# Altium schematic sheet JTAG.SchDoc
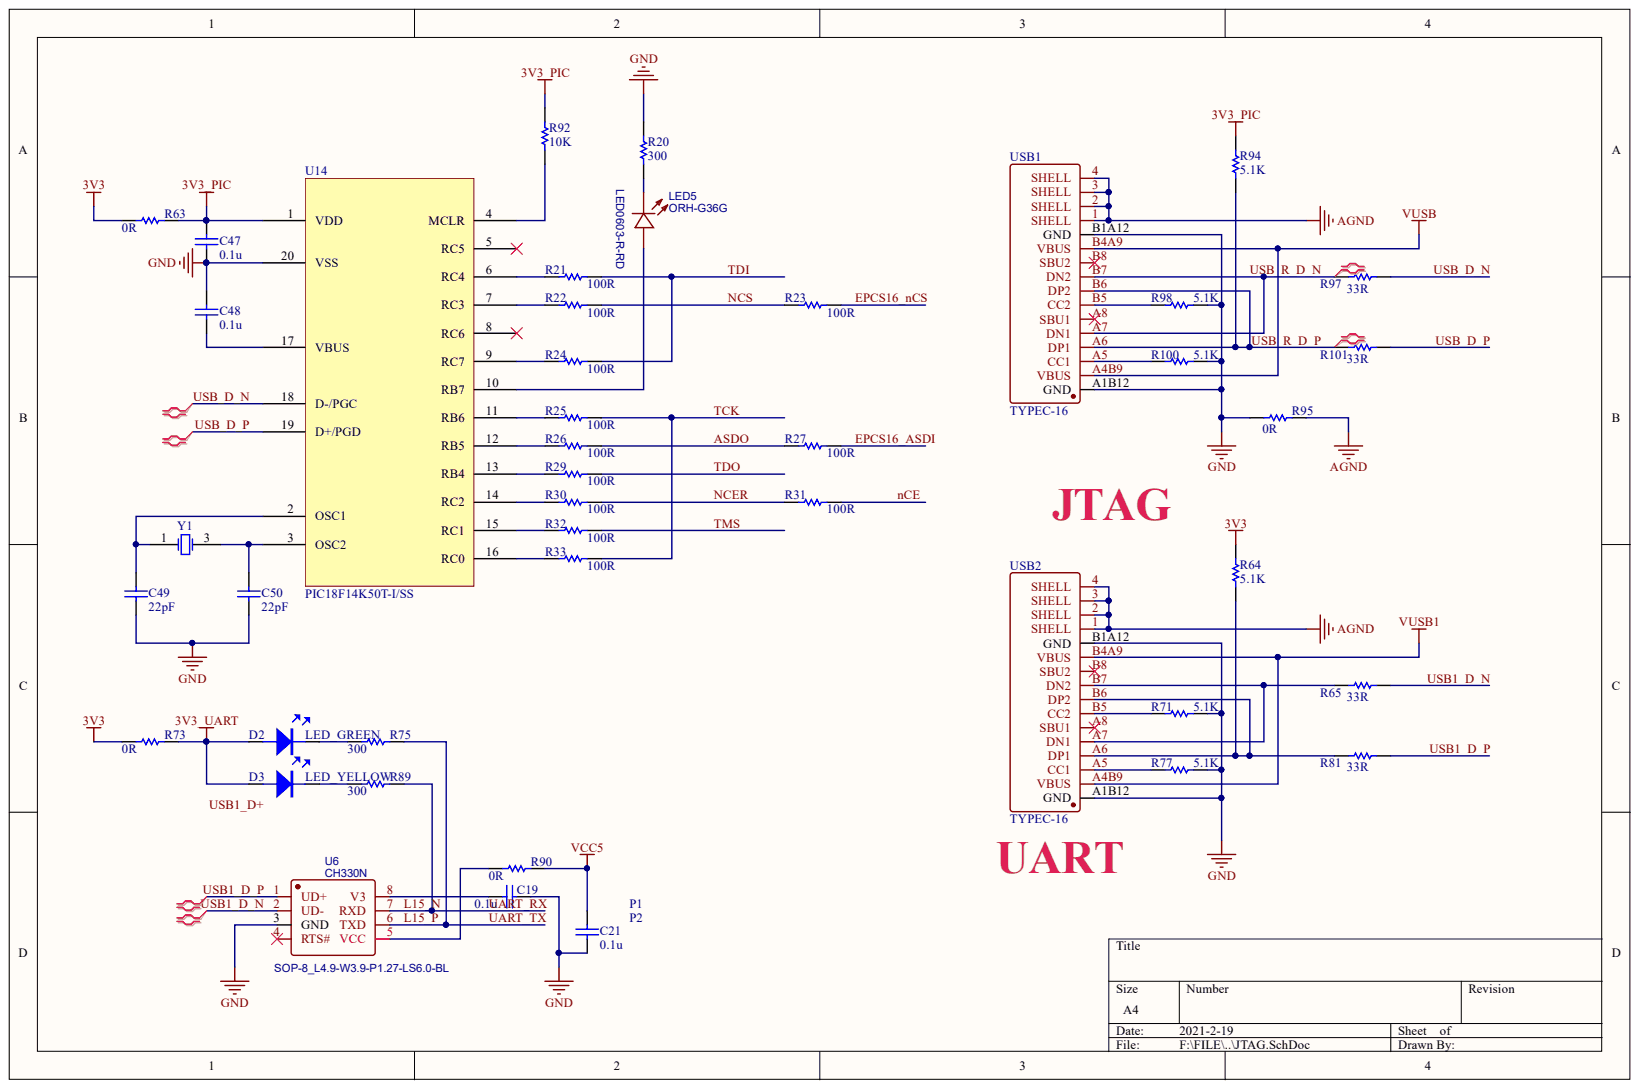
[diagram p0: JTAG.SchDoc]

## Parts
C19  0.1u  [C0402]
C21  0.1u  [C0402]
C47  0.1u  [C0402]
C48  0.1u  [C0402]
C49  22pF  [C0402]
C50  22pF  [C0402]
D2  LED_GREEN  [LED-0603G]
D3  LED_YELLOW  [LED-0603Y]
LED5  ORH-G36G  [LED0603-R-RD]
R20  300  [R0402]
R21  100R  [R0402]
R22  100R  [R0402]
R23  100R  [R0402]
R24  100R  [R0402]
R25  100R  [R0402]
R26  100R  [R0402]
R27  100R  [R0402]
R29  100R  [R0402]
R30  100R  [R0402]
R31  100R  [R0402]
R32  100R  [R0402]
R33  100R  [R0402]
R63  0R  [0603_Q0603A]
R64  5.1K  [R0402]
R65  33R  [R0402]
R71  5.1K  [R0402]
R73  0R  [R0402]
R75  300  [R0402]
R77  5.1K  [R0402]
R81  33R  [R0402]
R89  300  [R0402]
R90  0R  [0603_Q0603A]
R92  10K  [R0402]
R94  5.1K  [R0402]
R95  0R  [0603_Q0603A]
R97  33R  [R0402]
R98  5.1K  [R0402]
R100  5.1K  [R0402]
R101  33R  [R0402]  (value not drawn)
U6  CH330N  [SOP-8_L4.9-W3.9-P1.27-LS6.0-BL]
U14  PIC18F14K50T-I/SS  [PIC18F14K50T]  (value not drawn)
USB1  TYPEC-16  [USB-C-16PIN]
USB2  TYPEC-16  [USB-C-16PIN]
Y1  12MHZ 20PF 10PPM  [SMD-3225_4P]  (value not drawn)

## Nets
3V3: R63.2 R64.1 R73.2
3V3_PIC: C47.2 R63.1 R92.1 R94.1 U14.1(VDD)
3V3_UART: D2.A D3.A R73.1
AGND: R95.1 USB1.1(SHELL) USB1.2(SHELL) USB1.3(SHELL) USB1.4(SHELL) USB2.1(SHELL) USB2.2(SHELL) USB2.3(SHELL) USB2.4(SHELL)
GND: C19.1 C21.2 C47.1 C48.2 C49.1 C50.1 R20.2 R71.1 R77.1 R95.2 R98.1 R100.1 U6.3(GND) U14.20(VSS) USB1.A1B12(GND) USB1.B1A12(GND) USB2.A1B12(GND) USB2.B1A12(GND)
VCC5: C21.1 R90.1
VUSB: USB1.A4B9(VBUS) USB1.B4A9(VBUS)
VUSB1: USB2.A4B9(VBUS) USB2.B4A9(VBUS)
ASDO: R26.2 R27.1
EPCS16_ASDI: R27.2
EPCS16_NCS: R23.2
L15_N: R89.2 U6.7(RXD)
L15_P: R75.2 U6.6(TXD)
NCE: R31.2
NCER: R30.2 R31.1
NCS: R22.2 R23.1
TCK: R25.2 R33.2
TDI: R21.2 R24.2
TDO: R29.2
TMS: R32.2
USB1_D_N: R65.1 U6.2(UD-)
USB1_D_P: R81.1 U6.1(UD+)
USB_D_N: R97.1 U14.18(D-/PGC)
USB_D_P: R101.1 U14.19(D+/PGD)
USB_R_D_N: R97.2 USB1.A7(DN1) USB1.B7(DN2)
USB_R_D_P: R94.2 R101.2 USB1.A6(DP1) USB1.B6(DP2)
NetC19_2: C19.2 U6.8(V3)
NetC48_1: C48.1 U14.17(VBUS)
NetC49_2: C49.2 U14.2(OSC1) Y1.1
NetC50_2: C50.2 U14.3(OSC2) Y1.3
NetD2_K: D2.K R75.1
NetD3_K: D3.K R89.1
NetLED5_1: LED5.1 U14.10(RB7)
NetLED5_2: LED5.2 R20.1
NetR21_1: R21.1 U14.6(RC4)
NetR22_1: R22.1 U14.7(RC3)
NetR24_1: R24.1 U14.9(RC7)
NetR25_1: R25.1 U14.11(RB6)
NetR26_1: R26.1 U14.12(RB5)
NetR29_1: R29.1 U14.13(RB4)
NetR30_1: R30.1 U14.14(RC2)
NetR32_1: R32.1 U14.15(RC1)
NetR33_1: R33.1 U14.16(RC0)
NetR64_2: R64.2 R81.2 USB2.A6(DP1) USB2.B6(DP2)
NetR65_2: R65.2 USB2.A7(DN1) USB2.B7(DN2)
NetR71_2: R71.2 USB2.B5(CC2)
NetR77_2: R77.2 USB2.A5(CC1)
NetR90_2: R90.2 U6.5(VCC)
NetR92_2: R92.2 U14.4(MCLR)
NetR98_2: R98.2 USB1.B5(CC2)
NetR100_2: R100.2 USB1.A5(CC1)
USB1_D+:

Not connected: U6 4(RTS#); U14 5(RC5) 8(RC6); USB1 A8(SBU1) B8(SBU2); USB2 A8(SBU1) B8(SBU2)

## Library data of the parts
C19 C21 C47-C50 = CAP1: Component Kind: Standard; Library Name: the_god_of_death.IntLib
D2 D3 = LED: Component Kind: Standard; Library Name: LED.IntLib
LED5 = ORH-G36G: BOM_Manufacturer: Orient; Contributor: LCSC; Package Display: Yes; SMT Type: Extend; BOM_JLCPCB SMD: Yes; BOM_Manufacturer Part: ORH-G36G; BOM_Supplier: LCSC; BOM_Supplier Part: C205443; Component Kind: Standard; spicePre: L; spiceSymbolName: ORH-G36G
R20-R27 R29-R33 R63-R65 R71 R73 R75 R77 R81 R89 R90 R92 R94 R95 R97 R98 R100 R101 = RES: Component Kind: Standard
  R21-R27 R29-R33 R63-R65 R71 R73 R77 R81 R90 R92 R94 R95 R97 R98 R100 R101: Library Name: 电阻.IntLib
  R20 R75 R89: Library Name: the_god_of_death.IntLib
U6 = CH330N: BOM_Manufacturer: WCH; Package Display: Yes; BOM_Manufacturer Part: CH330N; BOM_Supplier: LCSC; BOM_Supplier Part: C108996; Component Kind: Standard; spicePre: U; spiceSymbolName: CH330N
USB1 USB2 = USB-C-16PIN: Component Kind: Standard; Library Name: USB.IntLib
Y1 = SMD-3225_4P  12MHZ 20PF 10PPM: 贴片晶振; Component Kind: Standard; ComponentLink1Description: 供应商链接; ComponentLink1URL: http://www.szlcsc.com/product/details_9522.html; Library Name: CrystalOscillator.SchLib; Package: SMD-3225_4P; Supplier: LC; SuppliersPartNumber: C9002
U14 = PIC18F14K50T-I/SS: Component Kind: Standard; Library Name: Schlib1.SchLib
